# Altium schematic sheet Sheet1.SchDoc(Sheet1)
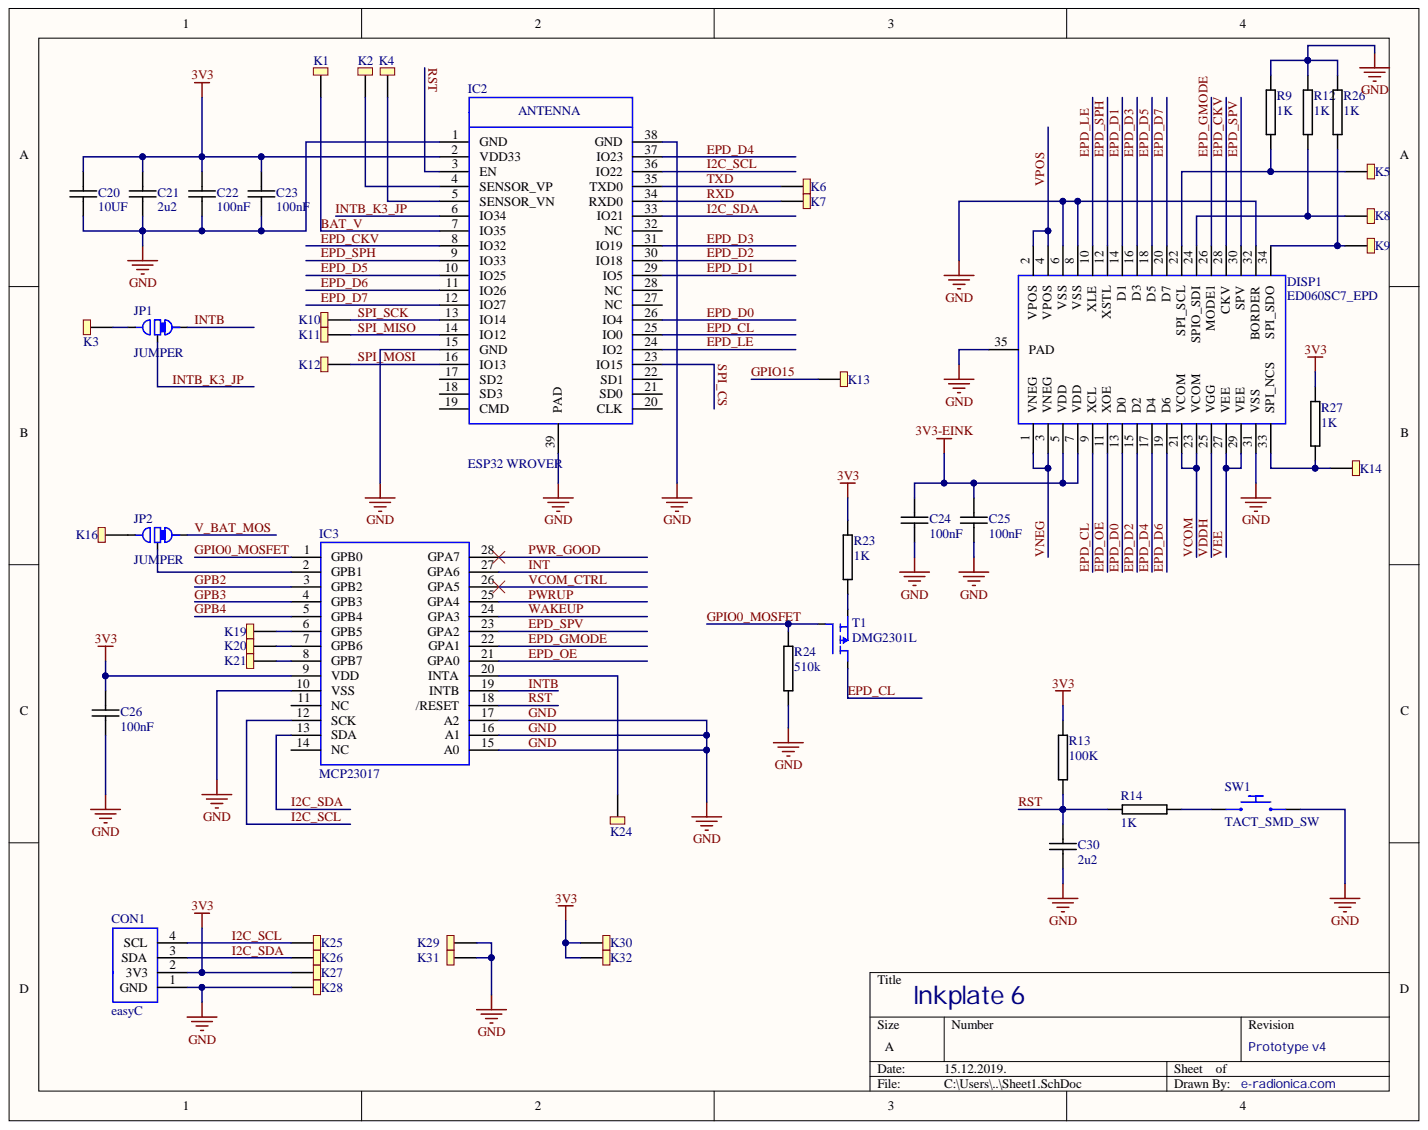
[diagram p0: Sheet1.SchDoc]

## Parts
C20  10UF  [1206C-GENERIC]
C21  2u2  [0603C-2U2]
C22  100nF  [0603C-GENERIC]
C23  100nF  [0603C-GENERIC]
C24  100nF  [0603C-GENERIC]
C25  100nF  [0603C-GENERIC]
C26  100nF  [0603C-GENERIC]
C30  2u2  [0603C-2U2]
CON1  easyC  [easyC]
DISP1  ED060SC7_EPD  [AXT334124]
IC2  ESP32 WROVER  [ESP32_WROVER]
IC3  MCP23017  [SOP28]
JP1  JUMPER  [SMD Jumper3 Closed]
JP2  JUMPER  [SMD Jumper3 Closed]
K1  HEADER-1x1  [PAD-1x1]  (value not drawn)
K2  HEADER-1x1  [PAD-1x1]  (value not drawn)
K3  HEADER-1x1  [PAD-1x1]  (value not drawn)
K4  HEADER-1x1  [PAD-1x1]  (value not drawn)
K5  HEADER-1x1  [PAD-1x1]  (value not drawn)
K6  HEADER-1x1  [PAD-1x1]  (value not drawn)
K7  HEADER-1x1  [PAD-1x1]  (value not drawn)
K8  HEADER-1x1  [PAD-1x1]  (value not drawn)
K9  HEADER-1x1  [PAD-1x1]  (value not drawn)
K10  HEADER-1x1  [PAD-1x1]  (value not drawn)
K11  HEADER-1x1  [PAD-1x1]  (value not drawn)
K12  HEADER-1x1  [PAD-1x1]  (value not drawn)
K13  HEADER-1x1  [PAD-1x1]  (value not drawn)
K14  HEADER-1x1  [PAD-1x1]  (value not drawn)
K16  HEADER-1x1  [PAD-1x1]  (value not drawn)
K19  HEADER-1x1  [PAD-1x1]  (value not drawn)
K20  HEADER-1x1  [PAD-1x1]  (value not drawn)
K21  HEADER-1x1  [PAD-1x1]  (value not drawn)
K24  HEADER-1x1  [PAD-1x1]  (value not drawn)
K25  HEADER-1x1  [PAD-1x1]  (value not drawn)
K26  HEADER-1x1  [PAD-1x1]  (value not drawn)
K27  HEADER-1x1  [PAD-1x1]  (value not drawn)
K28  HEADER-1x1  [PAD-1x1]  (value not drawn)
K29  HEADER-1x1  [PAD-1x1]  (value not drawn)
K30  HEADER-1x1  [PAD-1x1]  (value not drawn)
K31  HEADER-1x1  [PAD-1x1]  (value not drawn)
K32  HEADER-1x1  [PAD-1x1]  (value not drawn)
R9  1K  [0603R-1k]
R12  1K  [0603R-1k]
R13  100K  [0603R-100K]
R14  1K  Reel: R14  [0603R-1k]
R23  1K  [0603R-1k]
R24  510k  [0603R-GENERIC]
R26  1K  [0603R-1k]
R27  1K  [0603R-1k]
SW1  TACT_SMD_SW  [Pushbutton4x4]
T1  DMG2301L  [SOT90P240X110-3N]

## Nets
3V3: C20.1 C21.1 C22.1 C23.1 C26.1 CON1.2(3V3) IC2.2(VDD33) IC3.9(VDD) K27.1 K30.1 K32.1 R13.1 R23.1 R27.1
3V3-EINK: C24.1 C25.1 DISP1.5(VDD) DISP1.7(VDD)
BAT_V: IC2.7(IO35) K1.1
EPD_CKV: DISP1.28(CKV) IC2.8(IO32)
EPD_CL: DISP1.9(XCL) IC2.25(IO0) T1.2
EPD_D0: DISP1.13(D0) IC2.26(IO4)
EPD_D1: DISP1.14(D1) IC2.29(IO5)
EPD_D2: DISP1.15(D2) IC2.30(IO18)
EPD_D3: DISP1.16(D3) IC2.31(IO19)
EPD_D4: DISP1.17(D4) IC2.37(IO23)
EPD_D5: DISP1.18(D5) IC2.10(IO25)
EPD_D6: DISP1.19(D6) IC2.11(IO26)
EPD_D7: DISP1.20(D7) IC2.12(IO27)
EPD_GMODE: DISP1.26(MODE1) IC3.22(GPA1)
EPD_LE: DISP1.10(XLE) IC2.24(IO2)
EPD_OE: DISP1.11(XOE) IC3.21(GPA0)
EPD_SPH: DISP1.12(XSTL) IC2.9(IO33)
EPD_SPV: DISP1.30(SPV) IC3.23(GPA2)
GND: C20.2 C21.2 C22.2 C23.2 C24.2 C25.2 C26.2 C30.2 CON1.1(GND) DISP1.6(VSS) DISP1.8(VSS) DISP1.31(VSS) DISP1.32(BORDER) DISP1.35(PAD) IC2.1(GND) IC2.15(GND) IC2.38(GND) IC2.39(PAD) IC3.10(VSS) IC3.15(A0) IC3.16(A1) IC3.17(A2) K28.1 K29.1 K31.1 R9.1 R12.1 R24.2 R26.1 SW1.3
GPB2: IC3.3(GPB2)
GPB3: IC3.4(GPB3)
GPB4: IC3.5(GPB4)
GPIO0_MOSFET: IC3.1(GPB0) R24.1 T1.1
GPIO15: K13.1
I2C_SCL: CON1.4(SCL) IC2.36(IO22) IC3.12(SCK) K25.1
I2C_SDA: CON1.3(SDA) IC2.33(IO21) IC3.13(SDA) K26.1
INT: IC3.27(GPA6)
INTB: IC3.19(INTB) JP1.1
INTB_K3_JP: IC2.6(IO34) JP1.2
PWR_GOOD: IC3.28(GPA7)
PWRUP: IC3.25(GPA4)
RST: C30.1 IC2.3(EN) IC3.18(/RESET) R13.2 R14.1
RXD: IC2.34(RXD0) K7.1
SPI_CS: IC2.23(IO15)
SPI_MISO: IC2.14(IO12) K11.1
SPI_MOSI: IC2.16(IO13) K12.1
SPI_SCK: IC2.13(IO14) K10.1
TXD: IC2.35(TXD0) K6.1
V_BAT_MOS: JP2.1
VCOM: DISP1.21(VCOM) DISP1.23(VCOM)
VCOM_CTRL: IC3.26(GPA5)
VDDH: DISP1.25(VGG)
VEE: DISP1.27(VEE) DISP1.29(VEE)
VNEG: DISP1.1(VNEG) DISP1.3(VNEG)
VPOS: DISP1.2(VPOS) DISP1.4(VPOS)
WAKEUP: IC3.24(GPA3)
NetDISP1_22: DISP1.22(SPI_SCL) K5.1 R9.2
NetDISP1_24: DISP1.24(SPIO_SDI) K8.1 R12.2
NetDISP1_33: DISP1.33(SPI_NCS) K14.1 R27.2
NetDISP1_34: DISP1.34(SPI_SDO) K9.1 R26.2
NetIC2_4: IC2.4(SENSOR_VP) K2.1
NetIC2_5: IC2.5(SENSOR_VN) K4.1
NetIC3_2: IC3.2(GPB1) JP2.2
NetIC3_6: IC3.6(GPB5) K19.1
NetIC3_7: IC3.7(GPB6) K20.1
NetIC3_8: IC3.8(GPB7) K21.1
NetIC3_20: IC3.20(INTA) K24.1
NetJP1_3: JP1.3 K3.1
NetJP2_3: JP2.3 K16.1
NetR14_2: R14.2 SW1.1
NetR23_2: R23.2 T1.3

Not connected: IC2 17(SD2) 18(SD3) 19(CMD) 20(CLK) 21(SD0) 22(SD1) 27(NC) 28(NC) 32(NC); IC3 11(NC) 14(NC)

## Library data of the parts
C20 = 1206C-10U-35V-X7R: GENERIC CAPACITOR NON POLARISED 1206; Manufacturer Part Number: GMK316F106ZL-T; Manufacturer: Taiyo Yuden; Reel: C90; Supplier Part Number 1: 587-1352-2-ND; Supplier 1: Digi-Key; Library Name: vh_altium_library.intLib; Component Kind: Standard
C21 = 0603C-2U2-10V-X5R-TDK: CAPACITOR 0603 CERAMIC; Manufacturer Part Number: C1608X5R1A225K; Manufacturer: TDK Corporation; Reel: C11; Supplier Part Number 1: 445-5166-2-ND; Supplier 1: Digi-Key; Library Name: vh_altium_library.intLib; Component Kind: Standard
C22-C26 = 0603C-100NF-25V-X7R-TDK: CAPACITOR 0603 CERAMIC; Manufacturer Part Number: C1608X7R1E104K; Manufacturer: TDK Corporation; Reel: C12; Supplier Part Number 1: 445-1316-2-ND; Supplier 1: Digi-Key; Library Name: vh_altium_library.intLib; Component Kind: Standard
C30 = 0603C-2U2-6V3-X5R-MUR: CAPACITOR 0603 CERAMIC; Reel: C20; Library Name: vh_altium_library.intLib; Component Kind: Standard
DISP1 = ED060SC7: 800x600 6 INCH EPD PANEL; Component Kind: Standard; Library Name: eink_library.IntLib
IC2 = ESP32WROVER: ESP32 WROVER WIFI MODULE; Component Kind: Standard; Library Name: eink_library.IntLib
IC3 = MCP23017: I2C I/O Expander; Component Kind: Standard; Library Name: eink_library.IntLib
JP1 JP2 = SMD_JUMPER3_CLOSED: Component Kind: Standard; Library Name: eink_library.IntLib
K1-K14 K16 K19-K21 K24-K32 = HEADER-1x1: HEADER 1x1; Component Kind: Standard; Library Name: eink_library.IntLib
R9 R12 R23 R26 R27 = 0603R-1K: GENERIC RESISTOR 0603; Manufacturer Part Number: RMCF 1/16 1K 1% R; Manufacturer: Stackpole Electronics Inc; Reel: R14; Supplier Part Number 1: RMCF0603FT1K00TR-ND; Supplier 1: Digi-Key; Library Name: vh_altium_library.intLib; Component Kind: Standard
R13 = 0603R-100K: GENERIC RESISTOR 0603; Manufacturer Part Number: RMCF 1/16 100K 1% R; Manufacturer: Stackpole Electronics Inc; Tolerance: ±1%; Temperature Coefficient: ±100ppm/°C; REEL: R47; Power (Watts): 0.1W, 1/10W; Supplier Part Number 1: RMCF0603FT100KTR-ND; Supplier Part Number: RMCF1/16100KFRCT-ND; Supplier 1: Digi-Key; Supplier: Digi-Key; RoHS: RoHS Compliant; Resistance In Ohms: 100K; Packaging: Cut Tape (CT); Library Name: vh_altium_library.intLib; Lead Style: Surface Mount (SMD - SMT); Composition: Thick Film; Component Kind: Standard; Category: Resistors; Case: 0603 (1608 metric)
R14 = 0603R-1K: GENERIC RESISTOR 0603; Manufacturer Part Number: RMCF 1/16 1K 1% R; Manufacturer: Stackpole Electronics Inc; Supplier Part Number 1: RMCF0603FT1K00TR-ND; Supplier 1: Digi-Key; Library Name: vh_altium_library.intLib; Component Kind: Standard
R24 = R0603-GENERIC: GENERIC RESISTOR 0603; Library Name: vh_altium_library.intLib; Component Kind: Standard
CON1 = easyC: Component Kind: Standard; Library Name: eink_library.IntLib
SW1 = TACT_SMD_SW: Library Name: SchComponents.SchLib; Component Kind: Standard
T1 = DMG2301L: Component Kind: Standard; Library Name: eink_library.IntLib
